# Altium schematic sheet 300_Logic.SchDoc
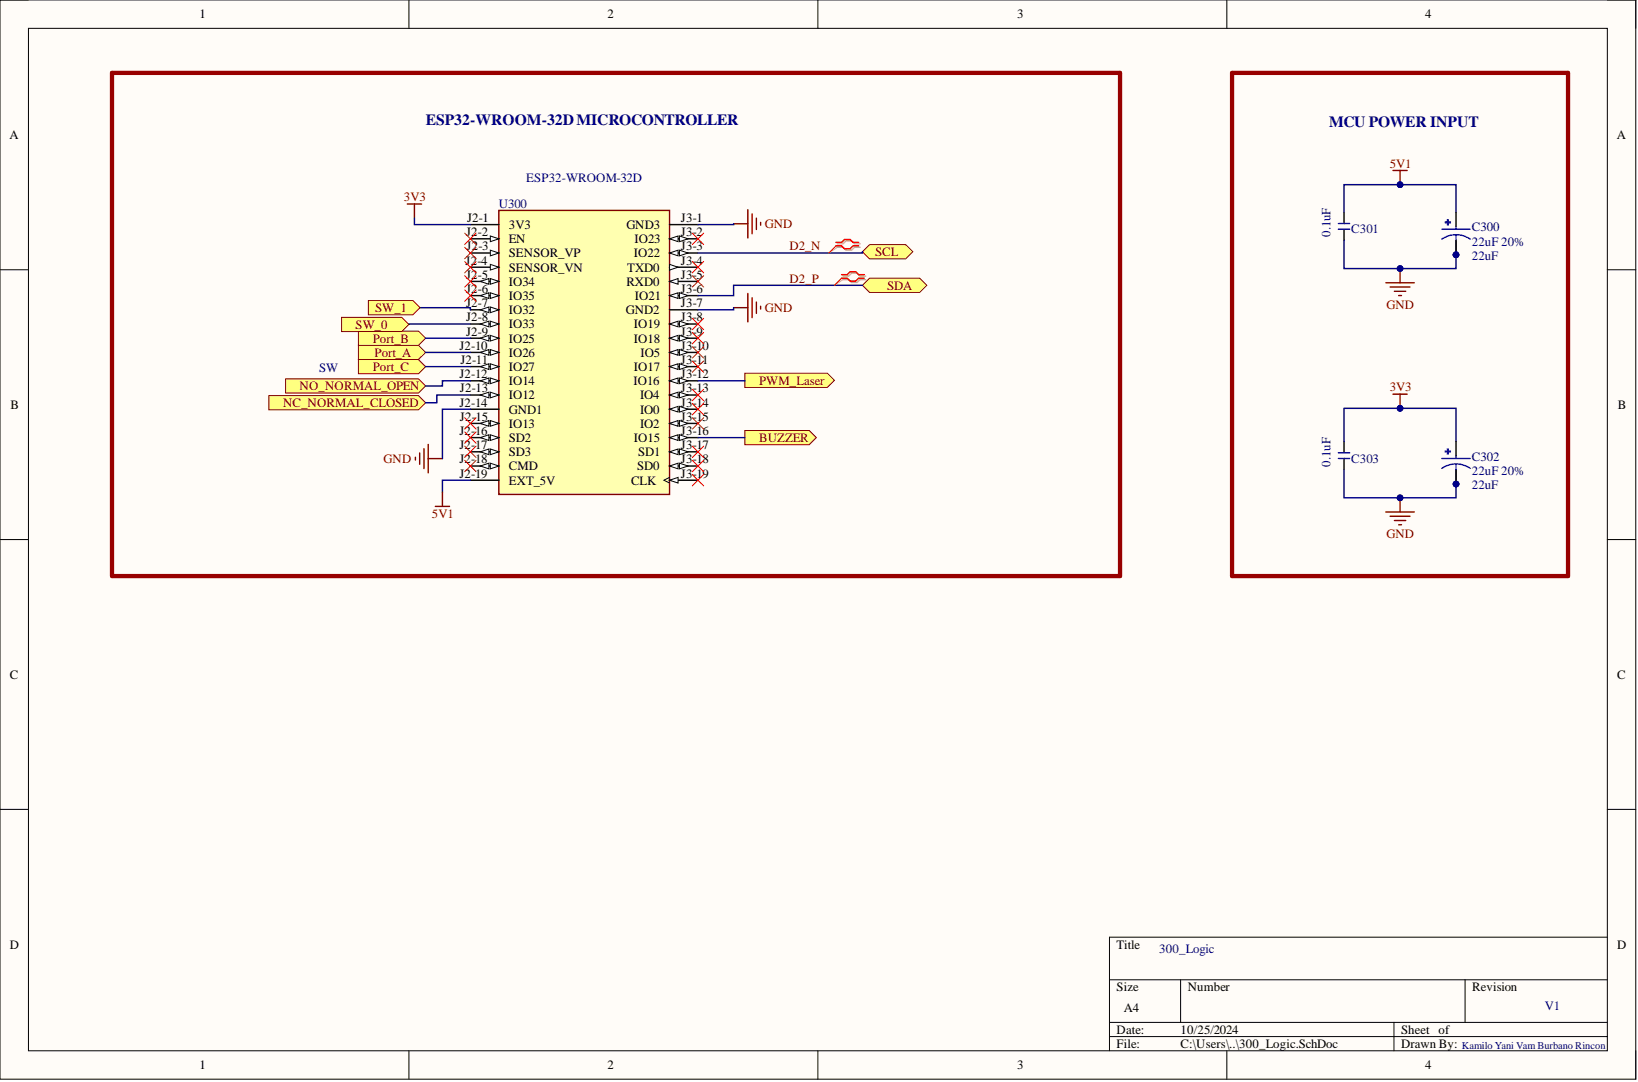
[diagram p0: 300_Logic.SchDoc]

## Parts
C300  22uF 20%  value 22uF  [CAP_THT_RADIAL_2]
C301  value 0.1uF  [CAP_THT_3.0mm]  (hidden comment: 0.1uF 20%)
C302  22uF 20%  value 22uF  [CAP_THT_RADIAL_2]
C303  value 0.1uF  [CAP_THT_3.0mm]  (hidden comment: 0.1uF 20%)
U300  ESP32-WROOM-32D  [MOD_WIFI_ESP32_WROOM32D_38_28x54.4mm]

## Ports
BUZZER, NC_NORMAL_CLOSED, NO_NORMAL_OPEN, Port_A, Port_B, Port_C, PWM_Laser, SCL, SDA, SW_0, SW_1

## Nets
3V3: C302.P C303.2 U300.J2-1(3V3)
5V1: C300.P C301.2 U300.J2-19(EXT_5V)
GND: C300.N C301.1 C302.N C303.1 U300.J2-14(GND1) U300.J3-1(GND3) U300.J3-7(GND2)
BUZZER: U300.J3-16(IO15) ; port BUZZER
D2_N: U300.J3-3(IO22) ; port SCL
D2_P: U300.J3-6(IO21) ; port SDA
NC_NORMAL_CLOSED: U300.J2-13(IO12) ; port NC_NORMAL_CLOSED
NO_NORMAL_OPEN: U300.J2-12(IO14) ; port NO_NORMAL_OPEN
Port_A: U300.J2-10(IO26) ; port Port_A
Port_B: U300.J2-9(IO25) ; port Port_B
Port_C: U300.J2-11(IO27) ; port Port_C
PWM_Laser: U300.J3-12(IO16) ; port PWM_Laser
SW_0: U300.J2-8(IO33) ; port SW_0
SW_1: U300.J2-7(IO32) ; port SW_1

Not connected: U300 J2-2(EN) J2-3(SENSOR_VP) J2-4(SENSOR_VN) J2-5(IO34) J2-6(IO35) J2-15(IO13) J2-16(SD2) J2-17(SD3) J2-18(CMD) J3-2(IO23) J3-4(TXD0) J3-5(RXD0) J3-8(IO19) J3-9(IO18) J3-10(IO5) J3-11(IO17) J3-13(IO4) J3-14(IO0) J3-15(IO2) J3-17(SD1) J3-18(SD0) J3-19(CLK)

## Library data of the parts
C300 C302 = Cap_22uF_16V_THT: 22uF   ±20% 50V Electrolict Capacitor Y5V; Component Kind: Standard; ComponentLink1Description: VISTRONICA; Last modified date: 2024055; Library Name: Library_laser.IntLib; Supplier 1: VISTRONICA; Last modified by: K-Y.Burbano
C301 C303 = Cap_0.1uF_50V_THT: 0.1uF   ±20% 50V Ceramic Capacitor Y5V; Component Kind: Standard; ComponentLink1Description: VISTRONICA; ComponentLink1URL: https://www.vistronica.com/componentes-pasivos/capacitores/condensador-ceramico-104-01uf-100nf--detail.html; Last modified date: 2024055; Supplier 1: VISTRONICA; Last modified by: K-Y.Burbano; Library Name: Library_Kam_ilo.IntLib
U300 = IC_MCU_ESP32-WROOM-32D: Chip: ESP32-WROOM-32D  Voltaje de funcionamiento: 5V  Con chip USB a UART  Puerto microUSB  Con antena on-board  Alimentación vía USB o por pines (3.3V-5V) (Use solo una de las opciones)  Dimensiones: 28x54.4mm (con la antena); Manufacturer: Expresiff; Manufacturer Part Number: ESP32-WROOM-32D-DEVKIT; Component Kind: Standard; ComponentLink1Description: Electronica i+d; ComponentLink1URL: https://www.didacticaselectronicas.com/index.php/sistemas-de-desarrollo/espressif-systems/esp32/esp32-wroom-32d-devkit-detail; Last modified date: 20240510; Supplier 1: Electronica i+d; Last modified by: K-Y.Burbano; Library Name: Library_Kam_ilo.IntLib
